# Altium schematic sheet USB_C.SchDoc(USB_C)
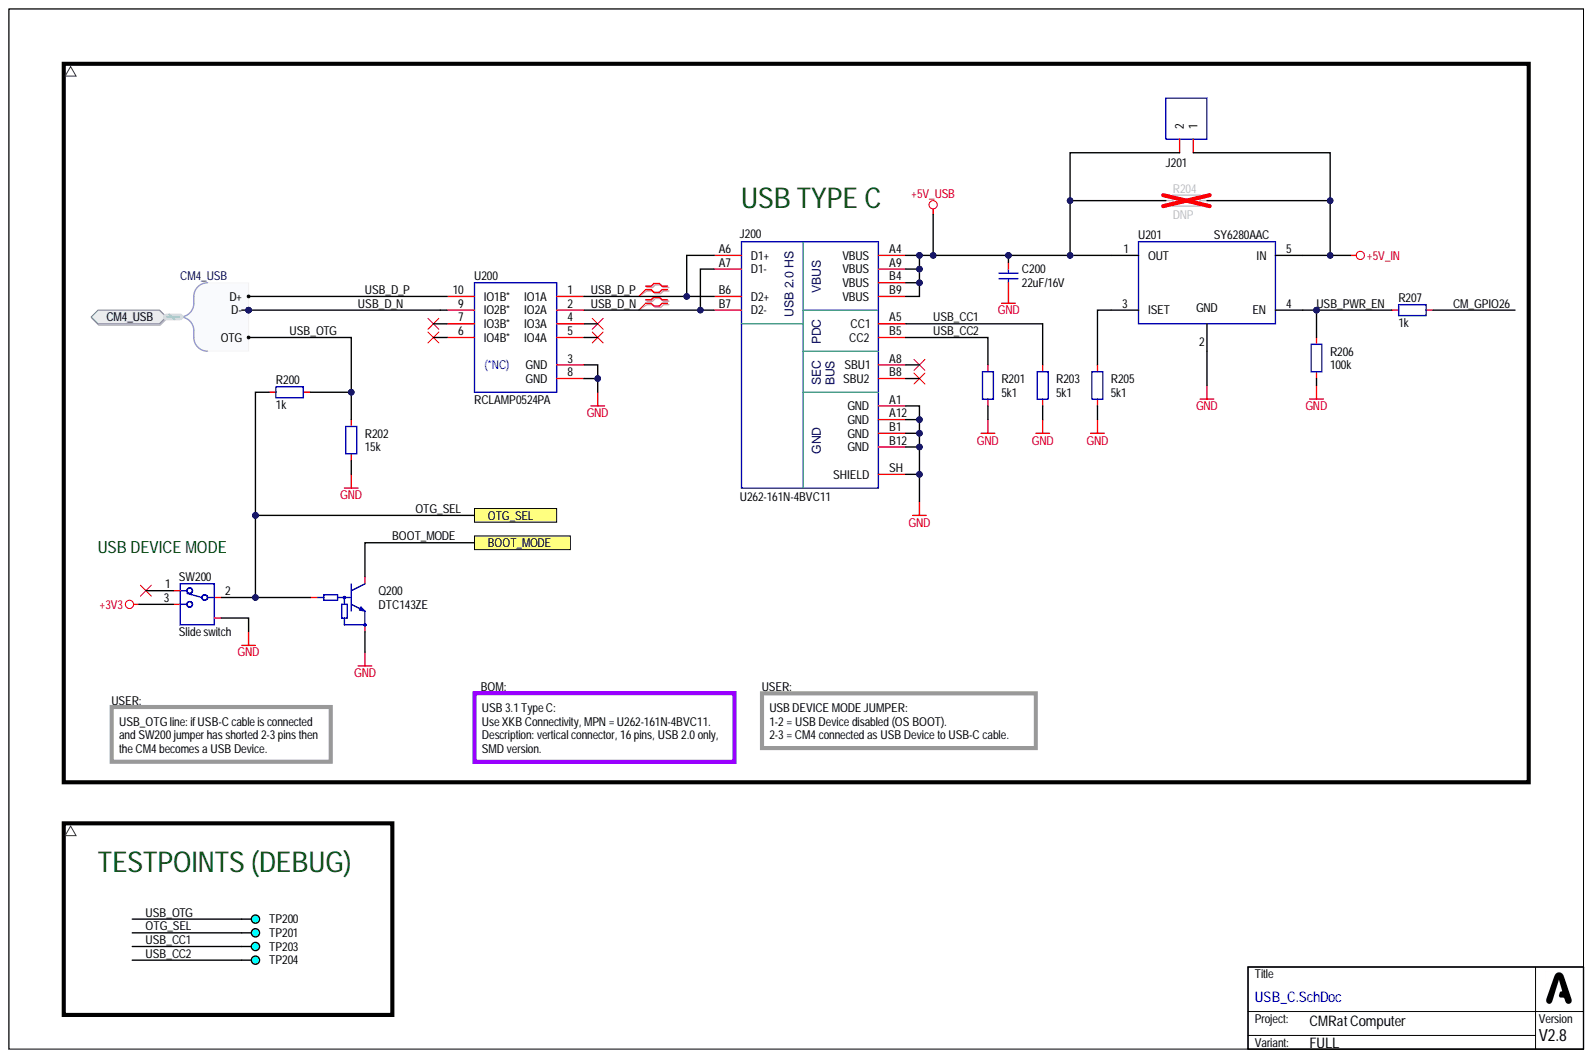
[diagram p0: USB_C.SchDoc]

## Parts
C200  22uF/16V  [CAP_0805_R]
J200  U262-161N-4BVC11  [CON_XKB_U262-161N-4BVC11]
J201  HDR 1x2  [CON_HDR_P254_1X02_STR]  (value not drawn)
Q200  DTC143ZE  [PKG_SOT523_SC75_SOT416_P100_R]
R200  1k  [RES_0603_R]
R201  5k1  [RES_0603_R]
R202  15k  [RES_0603_R]
R203  5k1  [RES_0603_R]
R204  DNP  [RES_1206_R]
R205  5k1  [RES_0603_R]
R206  100k  [RES_0603_R]
R207  1k  [RES_0603_R]
SW200  Slide switch  Component Subtype: Slide  [SWI_XKB_SS-3292S-L1]
TB?  =Value  (no BOM)
TP200  DEBUG  [PCB_TP_DBG_50]  (value not drawn)  (no BOM)
TP201  DEBUG  [PCB_TP_DBG_50]  (value not drawn)  (no BOM)
TP203  DEBUG  [PCB_TP_DBG_50]  (value not drawn)  (no BOM)
TP204  DEBUG  [PCB_TP_DBG_50]  (value not drawn)  (no BOM)
U200  RCLAMP0524PA  [ICC_SLP2510P8_250X100X60_P50_R]
U201  SY6280AAC  [PKG_SOT23-5_R]

## Ports
BOOT_MODE, CM4_USB, CM4_USB.D+, CM4_USB.D-, CM4_USB.OTG, OTG_SEL

## Nets
+3V3: SW200.3
+5V_IN: J201.1 R204.2 U201.5(IN)
+5V_USB: C200.2 J200.A4(VBUS) J200.A9(VBUS) J200.B4(VBUS) J200.B9(VBUS) J201.2 R204.1 U201.1(OUT)
GND: C200.1 J200.A1(GND) J200.A12(GND) J200.B1(GND) J200.B12(GND) J200.SH(SHIELD) Q200.2 R201.2 R202.1 R203.2 R205.2 R206.1 SW200.SH U200.3(GND) U200.8(GND) U201.2
BOOT_MODE: Q200.3 ; port BOOT_MODE
CM_GPIO26: R207.1
OTG_SEL: Q200.1 R200.2 SW200.2 TP201.1 ; port OTG_SEL
USB_CC1: J200.A5(CC1) R203.1 TP203.1
USB_CC2: J200.B5(CC2) R201.1 TP204.1
USB_D_N: J200.A7(D1-) J200.B7(D2-) U200.2(IO2A) U200.9(IO2B*) ; port CM4_USB
USB_D_P: J200.A6(D1+) J200.B6(D2+) U200.1(IO1A) U200.10(IO1B*) ; port CM4_USB
USB_OTG: R200.1 R202.2 TP200.1 ; port CM4_USB
USB_PWR_EN: R206.2 R207.2 U201.4(EN)
NetR205_1: R205.1 U201.3(ISET)

Not connected: J200 A8(SBU1) B8(SBU2); SW200 1; U200 4(IO3A) 5(IO4A) 6(IO4B*) 7(IO3B*)

## Library data of the parts
C200 = CAP-0024: Capacitor; MLCC; 22u 16V; Manufacturer: Samsung Electro-Mechanics; MPN: CL21A226MOQNNNE; Database Table Name: CAPACITOR$; Package (Case): 0805; Component Kind: Standard; Component Subtype: MLCC; Component Type: Capacitor; Mounting Type: SMD; Value: 22uF/16V; Library Name: MirFol_db_local.DbLib
J200 = CON_USB_TYPE_C_16P: Connector; USB 3.1 Type C; Receptacle; 24-pin; USB 2.0 Only;; Manufacturer: XKB Connectivity; Component Subtype: USB-C; Package (Case): SMD; Component Kind: Standard; Component Type: Connector; Mounting Type: SMD; MPN: U262-161N-4BVC11; Value: U262-161N-4BVC11; Library Name: MirFol_lib.SchLib
J201 = CON-0020: CONN THT / HEADER / PITCH 2,54mm / VERTICAL / (2 PIN); Manufacturer: Ckmtw(Shenzhen Cankemeng); MPN: B-2100S02P-A110; Component Subtype: Pin Header; Database Table Name: CONNECTOR$; Component Kind: Standard; Component Type: Connector; Mounting Type: THT; Package (Case): THT; Value: HDR 1x2; Library Name: MirFol_db_local.DbLib
Q200 = TRA_BJT_NPN_DIG_BEC: Transistor; BJT; NPN; Digital;; Manufacturer: On Semiconductor; Component Subtype: NPN Transistor; Package (Case): SOT-523; Component Kind: Standard; Component Type: Transistor; Mounting Type: SMD; MPN: DTC143ZE; Value: DTC143ZE; Library Name: MirFol_lib.SchLib
R200 R207 = RES-0027: Resistor; Thick Film; 1k; 1%; 100mW; 100PPM; 75V; Standard; SMD; 0603 (1608);; Manufacturer: UNI-ROYAL(Uniroyal Elec); MPN: 0603WAF1001T5E; Database Table Name: RESISTOR$; Package (Case): 0603; Component Kind: Standard; Component Subtype: Thick Film; Component Type: Resistor; Mounting Type: SMD; Value: 1k; Library Name: MirFol_db_local.DbLib
R201 R203 R205 = RES-0043: Resistor; Thick Film; 5k1; 1%; 100mW; 100PPM; 75V; Standard; SMD; 0603 (1608);; Manufacturer: UNI-ROYAL(Uniroyal Elec); MPN: 0603WAF5101T5E; Database Table Name: RESISTOR$; Package (Case): 0603; Component Kind: Standard; Component Subtype: Thick Film; Component Type: Resistor; Mounting Type: SMD; Value: 5k1; Library Name: MirFol_db_local.DbLib
R202 = RES-0043: Resistor; Thick Film; 15k; 1%; 100mW; 100PPM; 75V; Standard; SMD; 0603 (1608);; Manufacturer: UNI-ROYAL(Uniroyal Elec); MPN: 0603WAF1502T5E; Database Table Name: RESISTOR$; Package (Case): 0603; Component Kind: Standard; Component Subtype: Thick Film; Component Type: Resistor; Mounting Type: SMD; Value: 15k; Library Name: MirFol_db_local.DbLib
R204 = RES-0060: Component Subtype: Thick Film; Database Table Name: RESISTOR$; Package (Case): 1206; Component Kind: Standard; Component Type: Resistor; Description: DNP; Mounting Type: SMD; Value: DNP; Library Name: MirFol_db_local.DbLib
R206 = RES-0029: Resistor; Thick Film; 100k; 1%; 100mW; 100PPM; 75V; Standard; SMD; 0603 (1608);; Manufacturer: UNI-ROYAL(Uniroyal Elec); MPN: 0603WAF1003T5E; Database Table Name: RESISTOR$; Package (Case): 0603; Component Kind: Standard; Component Subtype: Thick Film; Component Type: Resistor; Mounting Type: SMD; Value: 100k; Library Name: MirFol_db_local.DbLib
SW200 = SWI_SPDT_3P_SH1: Switch; SPDT;; Manufacturer: XKB Connectivity; MPN: SS-3292S-L1; Package (Case): SMD; Component Kind: Standard; Component Type: Switch; Mounting Type: SMD; Value: Slide switch; Library Name: MirFol_lib.SchLib
TB? = SCH_TITLE_BLOCK_SIMPLE_NODATE: Library Name: Symbols_NO_BOM.SchLib
TP200 TP201 TP203 TP204 = PCB_TP_DBG: PCB Marking; Test Point (Debug);; Component Type: Testpoint; Value: DEBUG; Library Name: MirFol_lib.SchLib
U200 = ICC-0005: IC; TVS Arrays; ESD Protection; 4-Channel; 17kV Air; 12kV Contact; 0.3pF Capitance; TBD; SMD; TBD;; Manufacturer: Semtech Corporation; MPN: RCLAMP0524PATCT; Database Table Name: IC (MISC)$; Package (Case): DFN-10(1x2.5); Component Kind: Standard; Component Subtype: TVS Arrays; Component Type: IC; Mounting Type: SMD; Value: RCLAMP0524PA; Library Name: MirFol_db_local.DbLib
U201 = ITEM_U10052: IC SMD / LOAD SWITCH / 2.4V - 5.5V (SOT-23-5); Manufacturer: Silergy Corp; Database Table Name: IC$; Component Kind: Standard; Library Name: DB_DBLIB.DbLib; Mounting Type: SMD; MPN: SY6280AAC; Package (Case): SOT-23-5; Value: SY6280AAC
